# Altium schematic sheet ELRS_2IN1.SchDoc
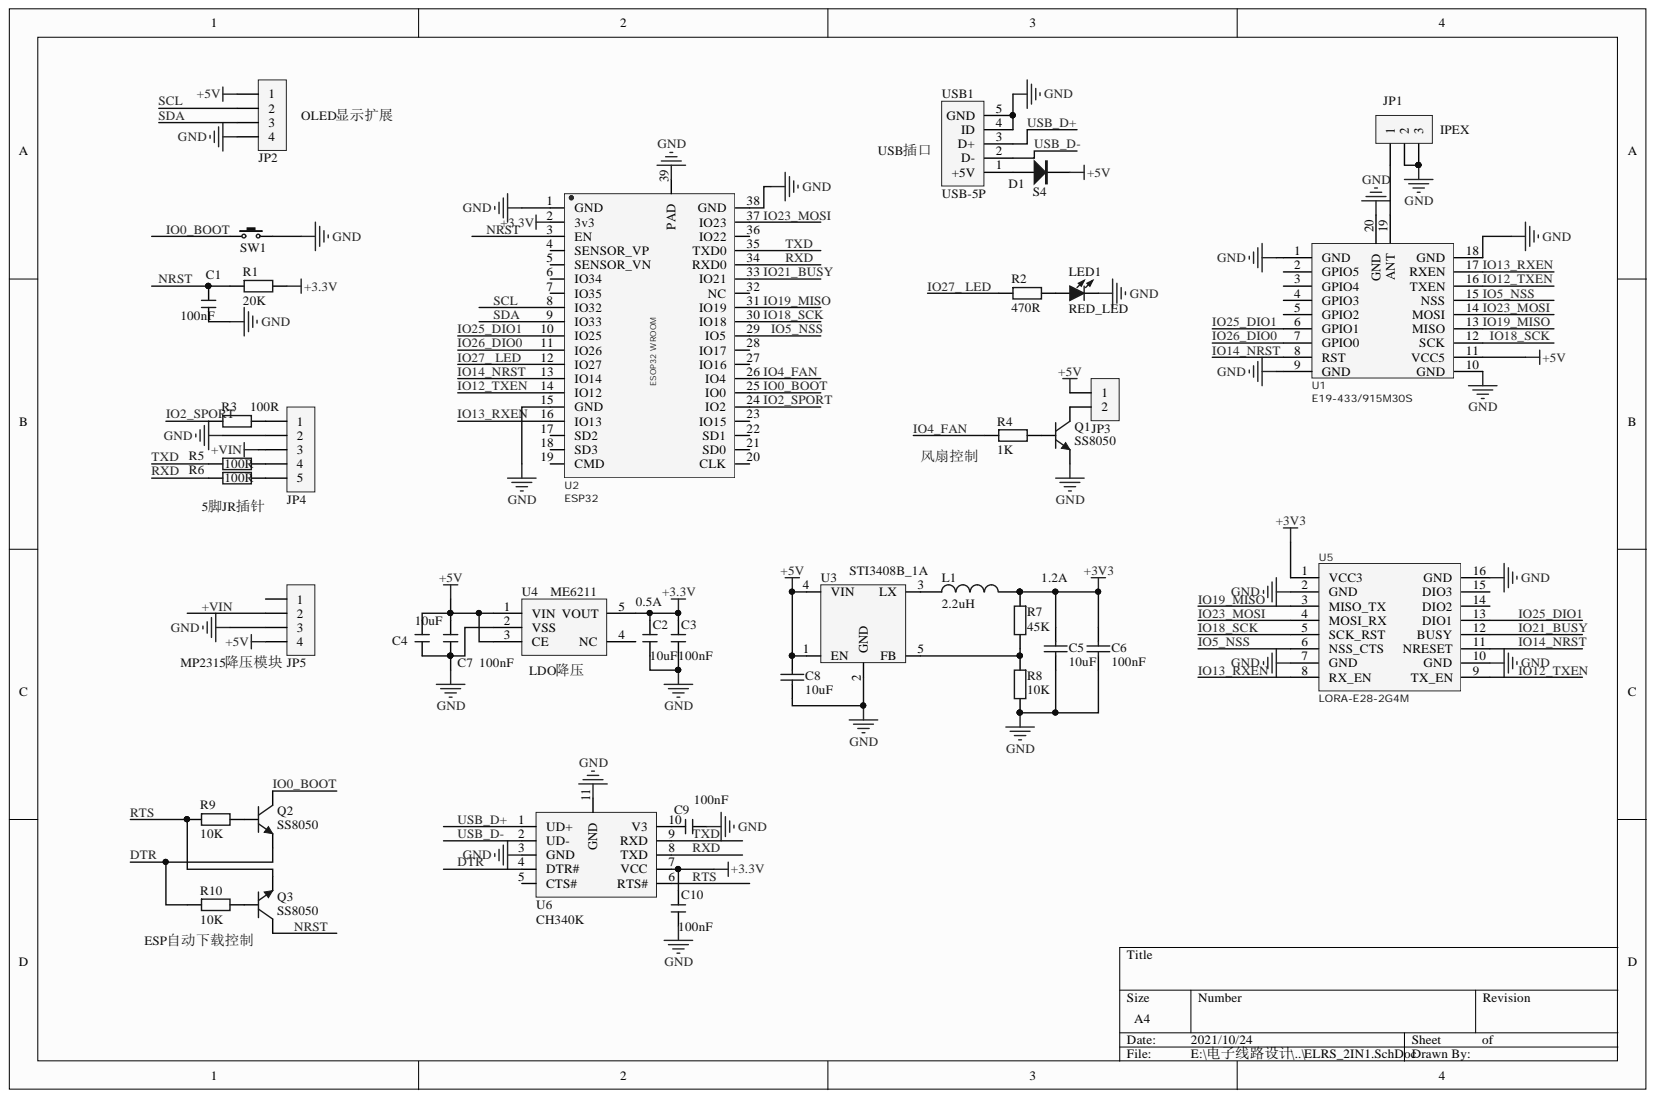
[diagram p0: ELRS_2IN1.SchDoc]

## Parts
C1  100nF  [C 0603_L]
C2  10uF  [C 0805_L]
C3  100nF  [C 0603_L]
C4  100nF  [C 0603_L]  (value not drawn)
C5  10uF  [C 0805_L]
C6  100nF  [C 0603_L]
C7  10uF  [C 0805_L]
C8  10uF  [C 0805_L]
C9  100nF  [C 0603_L]
C10  100nF  [C 0603_L]
D1  S4  [SOD123]
JP1  HDR-1X3  [IPEX]  (value not drawn)
JP2  HDR-1X4  [J-LINK]  (value not drawn)
JP3  HDR-1X2  [PH2.0-2]  (value not drawn)
JP4  HDR-1X5  [B2541BW-5P]  (value not drawn)
JP5  HDR-1X4  [MP2315-MODULE-SMT]  (value not drawn)
L1  2.2uH  [CD32]
LED1  RED_LED  [LED0805TB]
Q1  SS8050  [SOT23-3L]
Q2  SS8050  [SOT23-3L]
Q3  SS8050  [SOT23-3L]
R1  20K  [R 0603_L]
R2  470R  [R 0603_L]
R3  100R  [R 0603_L]
R4  1K  [R 0603_L]
R5  100R  [R 0603_L]
R6  100R  [R 0603_L]
R7  45K  [R 0603_L]
R8  10K  [R 0603_L]
R9  10K  [R 0603_L]
R10  10K  [R 0603_L]
SW1  FLASH  [KEY-K2]  (value not drawn)
U1  E19-433/915M30S  [E19_2IN1]
U2  ESP32  [ESP32]
U3  STI3408B_1A  [SOT23-5L]
U4  ME6211  [SOT23-5M]
U5  LORA-E28-2G4M  [E28-2G4M2XS]
U6  CH340K  [CH340K]
USB1  USB-5P  [USB-micro_C]

## Nets
+3.3V: C2.2 C3.2 C10.2 R1.1 U2.2(3v3) U4.5(VOUT) U6.7(VCC)
+3V3: C5.2 C6.2 L1.2 R7.2 U5.1(VCC3)
+5V: C4.2 C7.2 C8.2 D1.2 JP2.1 JP3.1 JP5.4 U1.11(VCC5) U3.1(EN) U3.4(VIN) U4.1(VIN) U4.3(CE)
GND: C1.1 C2.1 C3.1 C4.1 C5.1 C6.1 C7.1 C8.1 C9.1 C10.1 JP1.2 JP1.3 JP2.4 JP4.2 JP5.3 LED1.2 Q1.2 R8.1 SW1.2 U1.1(GND) U1.9(GND) U1.10(GND) U1.18(GND) U1.20(GND) U2.1(GND) U2.15(GND) U2.38(GND) U2.39(PAD) U3.2(GND) U4.2(VSS) U5.2(GND) U5.7(GND) U5.10(GND) U5.16(GND) U6.3(GND) U6.11(GND) USB1.4(ID) USB1.5(GND)
+VIN: JP4.3 JP5.2
DTR: Q2.2 R10.2 U6.4(DTR#)
IO0_BOOT: Q2.3 SW1.1 U2.25(IO0)
IO2_SPORT: R3.2 U2.24(IO2)
IO4_FAN: R4.2 U2.26(IO4)
IO5_NSS: U1.15(NSS) U2.29(IO5) U5.6(NSS_CTS)
IO12_TXEN: U1.16(TXEN) U2.14(IO12) U5.9(TX_EN)
IO13_RXEN: U1.17(RXEN) U2.16(IO13) U5.8(RX_EN)
IO14_NRST: U1.8(RST) U2.13(IO14) U5.11(NRESET)
IO18_SCK: U1.12(SCK) U2.30(IO18) U5.5(SCK_RST)
IO19_MISO: U1.13(MISO) U2.31(IO19) U5.3(MISO_TX)
IO21_BUSY: U2.33(IO21) U5.12(BUSY)
IO23_MOSI: U1.14(MOSI) U2.37(IO23) U5.4(MOSI_RX)
IO25_DIO1: U1.6(GPIO1) U2.10(IO25) U5.13(DIO1)
IO26_DIO0: U1.7(GPIO0) U2.11(IO26)
IO27_ LED: R2.2 U2.12(IO27)
NRST: C1.2 Q3.3 R1.2 U2.3(EN)
RTS: Q3.2 R9.2 U6.6(RTS#)
RXD: R6.2 U2.34(RXD0) U6.8(TXD)
SCL: JP2.2 U2.8(IO32)
SDA: JP2.3 U2.9(IO33)
TXD: R5.2 U2.35(TXD0) U6.9(RXD)
USB_D+: U6.1(UD+) USB1.3(D+)
USB_D-: U6.2(UD-) USB1.2(D-)
NetC9_2: C9.2 U6.10(V3)
NetD1_1: D1.1 USB1.1(+5V)
NetJP1_1: JP1.1 U1.19(ANT)
NetJP3_2: JP3.2 Q1.3
NetJP4_1: JP4.1 R3.1
NetJP4_4: JP4.4 R5.1
NetJP4_5: JP4.5 R6.1
NetL1_1: L1.1 U3.3(LX)
NetLED1_1: LED1.1 R2.1
NetQ1_1: Q1.1 R4.1
NetQ2_1: Q2.1 R9.1
NetQ3_1: Q3.1 R10.1
NetR7_1: R7.1 R8.2 U3.5(FB)

Not connected: JP5 1; U1 2(GPIO5) 3(GPIO4) 4(GPIO3) 5(GPIO2); U2 4(SENSOR_VP) 5(SENSOR_VN) 6(IO34) 7(IO35) 17(SD2) 18(SD3) 19(CMD) 20(CLK) 21(SD0) 22(SD1) 23(IO15) 27(IO16) 28(IO17) 32(NC) 36(IO22); U4 4(NC); U5 14(DIO2) 15(DIO3); U6 5(CTS#)

## Library data of the parts
C1-C4 C7 C9 C10 = C: 无极性贴片电容; 版权归属: 源创客; BOM名称: 无极性贴片电容; Component Kind: Standard; Library Name: 3.1   - 电阻-电容-电感.SchLib; 学习资源: www.ooocke.com
C5 C6 C8 = Cap: Capacitor; Component Kind: Standard; LatestRevisionDate: 17-Jul-2002; LatestRevisionNote: Re-released for DXP Platform.; Library Name: Miscellaneous Devices.IntLib; PackageDescription: Radial Cap, Thru-Hole; 2 Leads; 0.3 in Pin Spacing; PackageReference: RAD-0.3; Published: 8-Jun-2000; Signal Integrity: Cap; Simulation: CAP; Publisher: Altium Limited
D1 = SS14: 肖特基二极管; 版权归属: 源创客; 属性: 40V/1A; BOM名称: 肖特基二极管; Component Kind: Standard; Library Name: 8.1   - 二极管-整流桥.SchLib; 学习资源: www.ooocke.com
JP1 = Header 3: 3P接插件; 版权归属: 源创客; BOM名称: 3P接插件; Component Kind: Standard; Library Name: 4.3   - 接插件-脚距1.5.SchLib; 学习资源: www.ooocke.com
JP2 JP5 = Header 4: 4P接插件; 版权归属: 源创客; BOM名称: 4P接插件; Component Kind: Standard; Library Name: 4.5   - 接插件-脚距2.54.SchLib; 学习资源: www.ooocke.com
JP3 = Header 2: 2P接插件; 版权归属: 源创客; BOM名称: 2P接插件; Component Kind: Standard; Library Name: 4.3   - 接插件-脚距1.5.SchLib; 学习资源: www.ooocke.com
JP4 = Header 5: 5P接插件; 版权归属: 源创客; BOM名称: 5P接插件; Component Kind: Standard; Library Name: 4.5   - 接插件-脚距2.54.SchLib; 学习资源: www.ooocke.com
L1 = L: 小功率贴片电感; 版权归属: 源创客; BOM名称: 小功率贴片电感; Component Kind: Standard; Library Name: 3.1   - 电阻-电容-电感.SchLib; 学习资源: www.ooocke.com
LED1 = LED-SMD: 贴片LED; 版权归属: 源创客; BOM名称: 贴片LED; Component Kind: Standard; Library Name: 9.1   - LED.SchLib; 学习资源: www.ooocke.com
Q1-Q3 = 8050-SMD: 高频放大-NPN型; 版权归属: 源创客; 属性: 40V 1.5A 1W 100MHZ; BOM名称: 高频放大-NPN型; Component Kind: Standard; Library Name: 6.2   - 三极管.SchLib; 学习资源: www.ooocke.com
R1-R10 = R: 贴片电阻; 版权归属: 源创客; BOM名称: 贴片电阻; Component Kind: Standard; Library Name: 3.1   - 电阻-电容-电感.SchLib; 学习资源: www.ooocke.com
SW1 = TSW DIP-6x6_V: 侧按直插6x6轻触开关; 版权归属: 源创客; BOM名称: 直插6x6轻触开关; Component Kind: Standard; Library Name: 5.1   - 按键-开关.SchLib; 学习资源: www.ooocke.com
U1 = LORA-SX1276M: Component Kind: Standard; Library Name: Schlib.SchLib
USB1 = USB-5P: 微型USB母座; 版权归属: 源创客; BOM名称: 微型USB母座; Component Kind: Standard; Library Name: 4.1   - 接口类.SchLib; 学习资源: www.ooocke.com
U2 = ESP32: Component Kind: Standard; Library Name: Schlib.SchLib
U3 = STI3408B_1A: Component Kind: Standard; Library Name: Raspberry Pi.SchLib
U4 = ME6211: Component Kind: Standard; Library Name: MINI.SCHLIB
U5 = LORA-E28-2G4M: Component Kind: Standard; Library Name: Schlib.SchLib
U6 = CH340K: Component Kind: Standard; Library Name: Schlib.SchLib
